# Altium schematic sheet Sch2 - Switch e sensor.SchDoc("Sch2 - Switch e sensor")
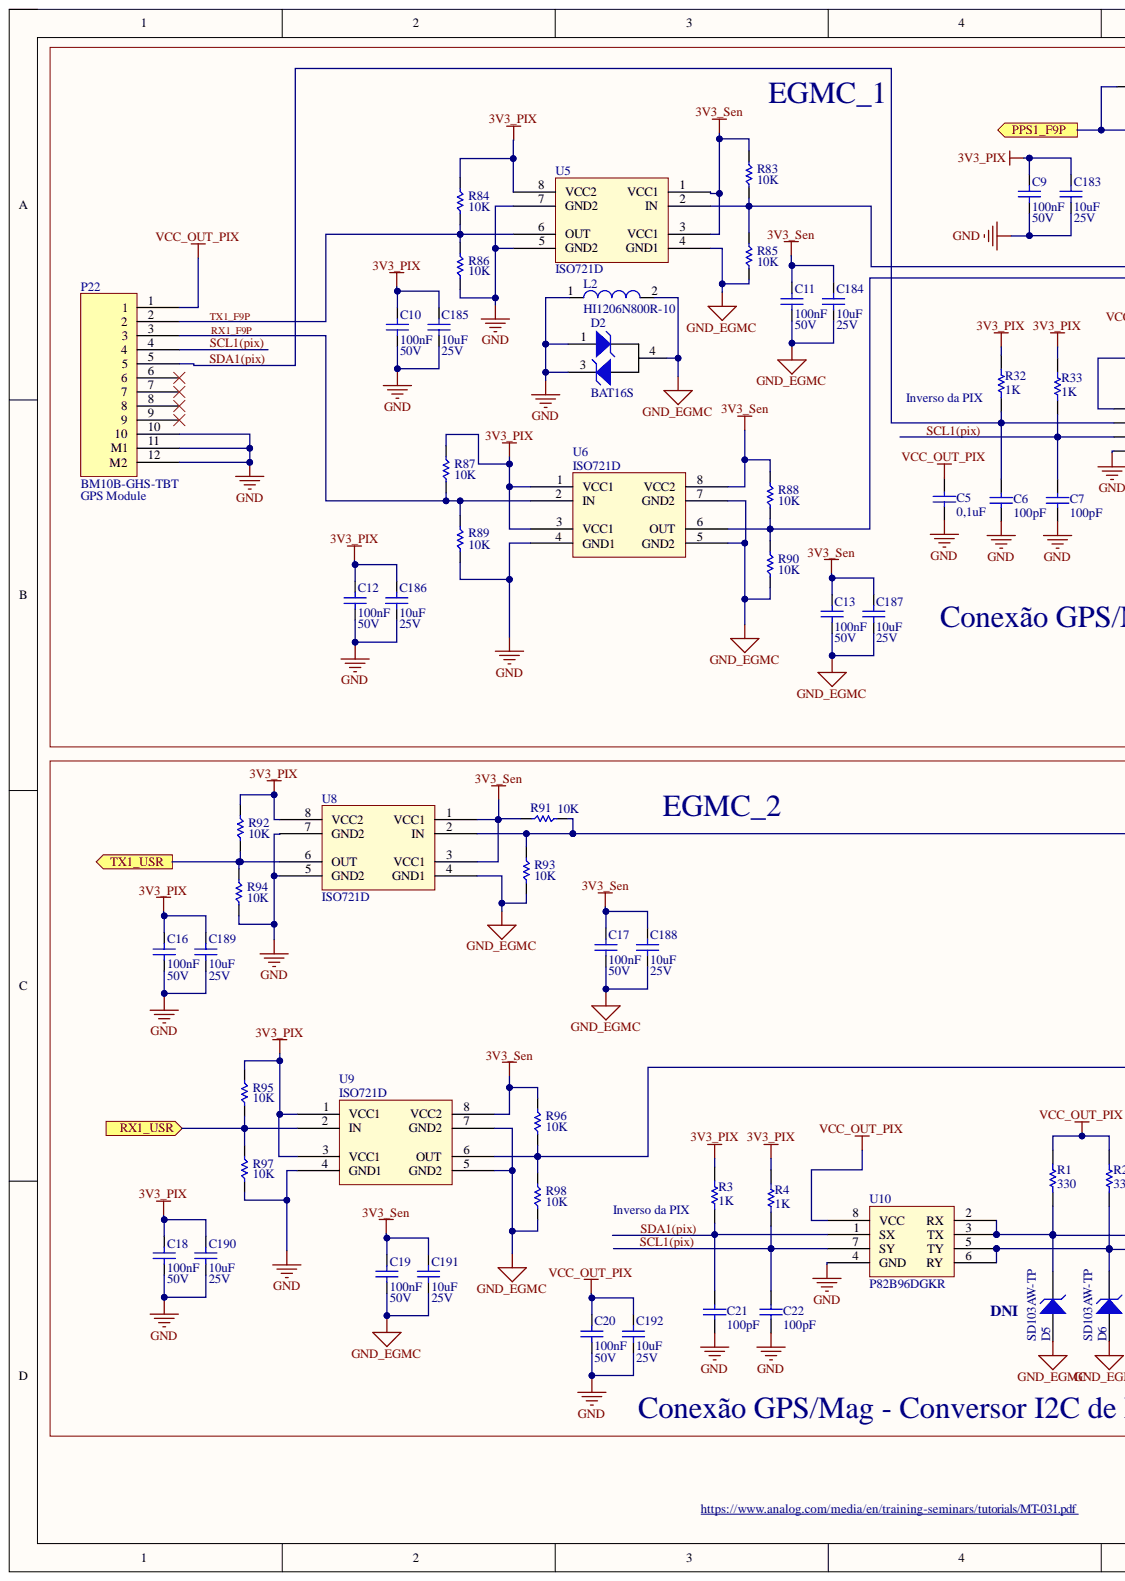
[diagram p0: Sch2 - Switch e sensor.SchDoc, left]
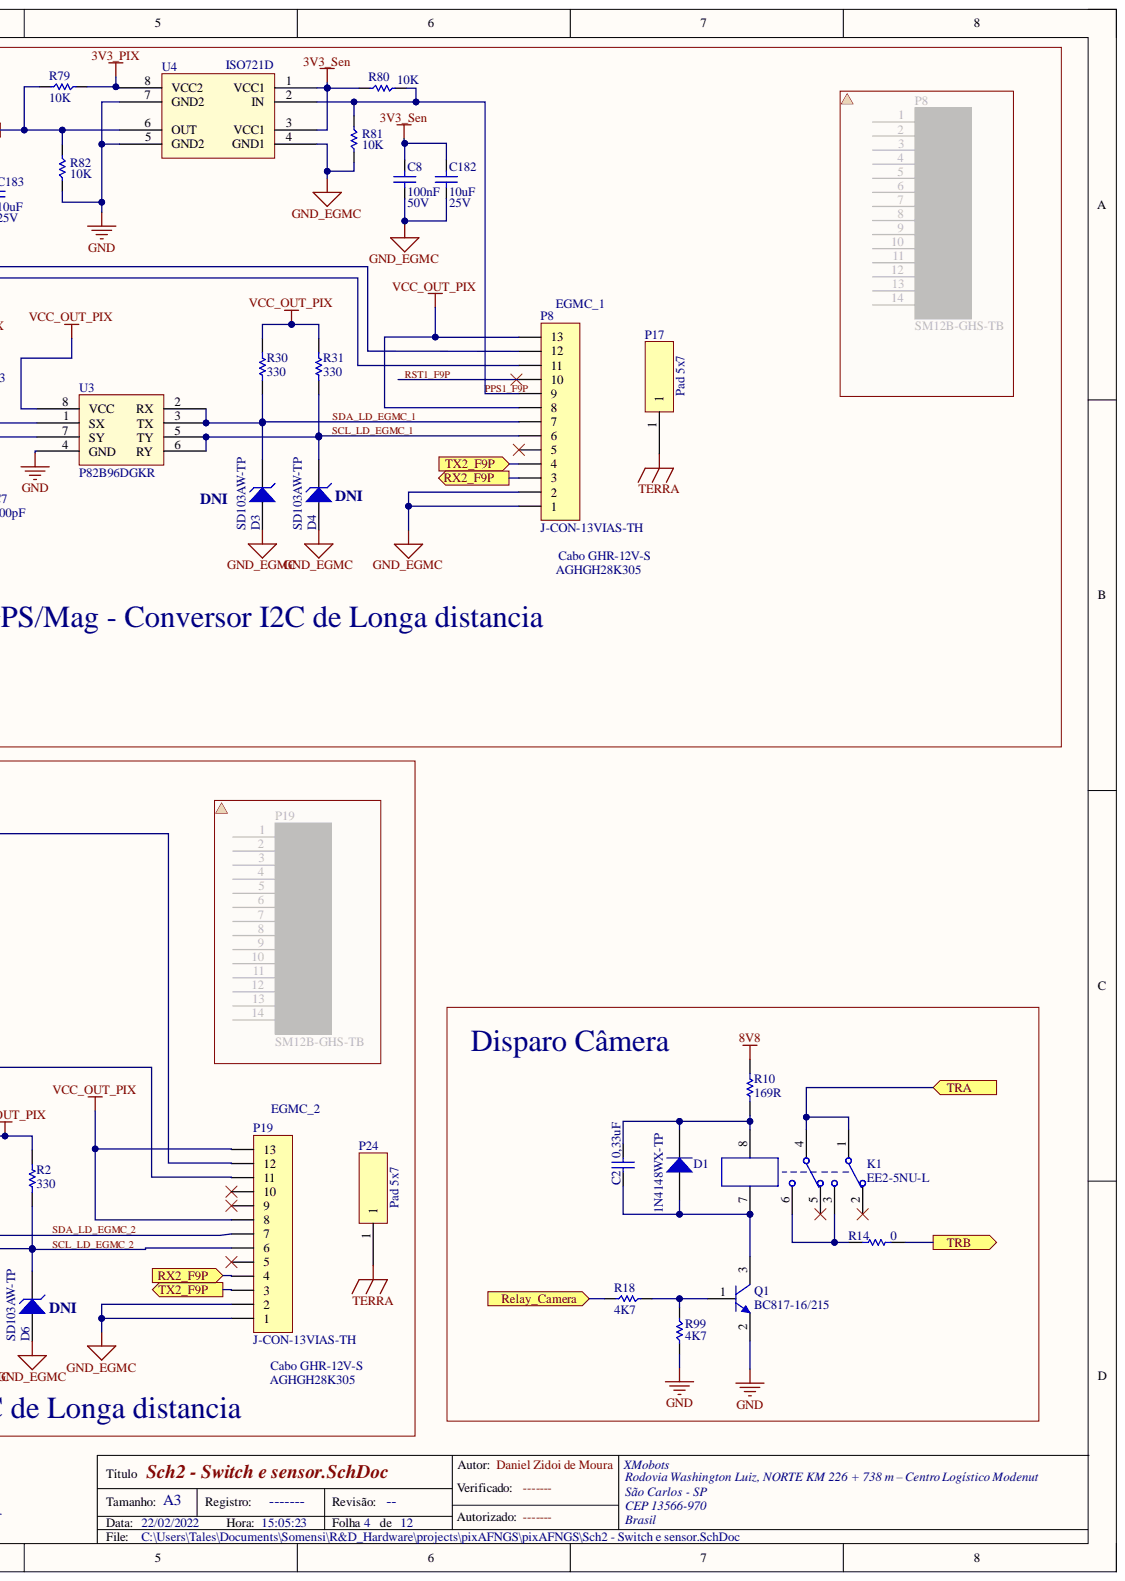
[diagram p1: Sch2 - Switch e sensor.SchDoc, right]

## Parts
C2  value 0,33uF  [0603]  (hidden comment: Cap Semi)
C5  value 0,1uF  [0603]  (hidden comment: Cap Semi)
C6  value 100pF  [0603]  (hidden comment: Cap Semi)
C7  value 100pF  [0603]  (hidden comment: Cap Semi)
C8  Capacitance: 100nF  Voltage: 50V  [0603]  (hidden comment: CL10B104MB8NNNC)
C9  Capacitance: 100nF  Voltage: 50V  [0603]  (hidden comment: CL10B104MB8NNNC)
C10  Capacitance: 100nF  Voltage: 50V  [0603]  (hidden comment: CL10B104MB8NNNC)
C11  Capacitance: 100nF  Voltage: 50V  [0603]  (hidden comment: CL10B104MB8NNNC)
C12  Capacitance: 100nF  Voltage: 50V  [0603]  (hidden comment: CL10B104MB8NNNC)
C13  Capacitance: 100nF  Voltage: 50V  [0603]  (hidden comment: CL10B104MB8NNNC)
C16  Capacitance: 100nF  Voltage: 50V  [0603]  (hidden comment: CL10B104MB8NNNC)
C17  Capacitance: 100nF  Voltage: 50V  [0603]  (hidden comment: CL10B104MB8NNNC)
C18  Capacitance: 100nF  Voltage: 50V  [0603]  (hidden comment: CL10B104MB8NNNC)
C19  Capacitance: 100nF  Voltage: 50V  [0603]  (hidden comment: CL10B104MB8NNNC)
C20  Capacitance: 100nF  Voltage: 50V  [0603]  (hidden comment: CL10B104MB8NNNC)
C21  value 100pF  [0603]  (hidden comment: Cap Semi)
C22  value 100pF  [0603]  (hidden comment: Cap Semi)
C182  Capacitance: 10uF  Voltage: 25V  [CAP-XMO-0603]  (hidden comment: GRM188R61E106KA73J)
C183  Capacitance: 10uF  Voltage: 25V  [CAP-XMO-0603]  (hidden comment: GRM188R61E106KA73J)
C184  Capacitance: 10uF  Voltage: 25V  [CAP-XMO-0603]  (hidden comment: GRM188R61E106KA73J)
C185  Capacitance: 10uF  Voltage: 25V  [CAP-XMO-0603]  (hidden comment: GRM188R61E106KA73J)
C186  Capacitance: 10uF  Voltage: 25V  [CAP-XMO-0603]  (hidden comment: GRM188R61E106KA73J)
C187  Capacitance: 10uF  Voltage: 25V  [CAP-XMO-0603]  (hidden comment: GRM188R61E106KA73J)
C188  Capacitance: 10uF  Voltage: 25V  [CAP-XMO-0603]  (hidden comment: GRM188R61E106KA73J)
C189  Capacitance: 10uF  Voltage: 25V  [CAP-XMO-0603]  (hidden comment: GRM188R61E106KA73J)
C190  Capacitance: 10uF  Voltage: 25V  [CAP-XMO-0603]  (hidden comment: GRM188R61E106KA73J)
C191  Capacitance: 10uF  Voltage: 25V  [CAP-XMO-0603]  (hidden comment: GRM188R61E106KA73J)
C192  Capacitance: 10uF  Voltage: 25V  [CAP-XMO-0603]  (hidden comment: GRM188R61E106KA73J)
D1  1N4148WX-TP  [SOD-323]
D2  BAT16S  [SOT223-4]
D3  SD103AW-TP  [SOD-123]
D4  SD103AW-TP  [SOD-123]
D5  SD103AW-TP  [SOD-123]
D6  SD103AW-TP  [SOD-123]
K1  EE2-5NU-L  [Relay TX2]
L2  HI1206N800R-10  [1206]
P8  J-CON-13VIAS-TH  [J-CON-13VIAS-TH]
P17  Pad 5x7  [Pad de solda 5x7]
P19  J-CON-13VIAS-TH  [J-CON-13VIAS-TH]
P22  BM10B-GHS-TBT  [BM10B-GHS-TBT]
P24  Pad 5x7  [Pad de solda 5x7]
Q1  BC817-16/215  [SOT23]
R1  value 330  [0603]  (hidden comment: Res Semi)
R2  value 330  [0603]  (hidden comment: Res Semi)
R3  value 1K  [0603]  (hidden comment: Res Semi)
R4  value 1K  [0603]  (hidden comment: Res Semi)
R10  value 169R  [1206]  (hidden comment: RC1206FR-07169RL)
R14  value 0  [0805]  (hidden comment: Res Semi)
R18  Resistance: 4K7  [Res_0603]  (hidden comment: RMCF0603FT4K70)
R30  value 330  [0603]  (hidden comment: Res Semi)
R31  value 330  [0603]  (hidden comment: Res Semi)
R32  value 1K  [0603]  (hidden comment: Res Semi)
R33  value 1K  [0603]  (hidden comment: Res Semi)
R79  Resistance: 10K  [RES-XMO-0603]  (hidden comment: RMCF0603FT10K0)
R80  Resistance: 10K  [RES-XMO-0603]  (hidden comment: RMCF0603FT10K0)
R81  Resistance: 10K  [RES-XMO-0603]  (hidden comment: RMCF0603FT10K0)
R82  Resistance: 10K  [RES-XMO-0603]  (hidden comment: RMCF0603FT10K0)
R83  Resistance: 10K  [RES-XMO-0603]  (hidden comment: RMCF0603FT10K0)
R84  Resistance: 10K  [RES-XMO-0603]  (hidden comment: RMCF0603FT10K0)
R85  Resistance: 10K  [RES-XMO-0603]  (hidden comment: RMCF0603FT10K0)
R86  Resistance: 10K  [RES-XMO-0603]  (hidden comment: RMCF0603FT10K0)
R87  Resistance: 10K  [RES-XMO-0603]  (hidden comment: RMCF0603FT10K0)
R88  Resistance: 10K  [RES-XMO-0603]  (hidden comment: RMCF0603FT10K0)
R89  Resistance: 10K  [RES-XMO-0603]  (hidden comment: RMCF0603FT10K0)
R90  Resistance: 10K  [RES-XMO-0603]  (hidden comment: RMCF0603FT10K0)
R91  Resistance: 10K  [RES-XMO-0603]  (hidden comment: RMCF0603FT10K0)
R92  Resistance: 10K  [RES-XMO-0603]  (hidden comment: RMCF0603FT10K0)
R93  Resistance: 10K  [RES-XMO-0603]  (hidden comment: RMCF0603FT10K0)
R94  Resistance: 10K  [RES-XMO-0603]  (hidden comment: RMCF0603FT10K0)
R95  Resistance: 10K  [RES-XMO-0603]  (hidden comment: RMCF0603FT10K0)
R96  Resistance: 10K  [RES-XMO-0603]  (hidden comment: RMCF0603FT10K0)
R97  Resistance: 10K  [RES-XMO-0603]  (hidden comment: RMCF0603FT10K0)
R98  Resistance: 10K  [RES-XMO-0603]  (hidden comment: RMCF0603FT10K0)
R99  Resistance: 4K7  [RES-XMO-0603]  (hidden comment: RMCF0603FT4K70)
U3  P82B96DGKR  [MSOP_8]
U4  ISO721D  [SOIC-8_D-PDSO-G8]
U5  ISO721D  [SOIC-8_D-PDSO-G8]
U6  ISO721D  [SOIC-8_D-PDSO-G8]
U8  ISO721D  [SOIC-8_D-PDSO-G8]
U9  ISO721D  [SOIC-8_D-PDSO-G8]
U10  P82B96DGKR  [MSOP_8]

## Ports
PPS1_F9P, Relay_Camera, RX1_USR, RX2_F9P, TRA, TRB, TX1_USR, TX2_F9P

## Nets
3V3_PIX: C9.2 C10.2 C12.2 C16.2 C18.2 C183.2 C185.2 C186.2 C189.2 C190.2 R3.1 R4.1 R32.1 R33.1 R79.2 R84.2 R87.2 R92.2 R95.2 U4.8(VCC2) U5.8(VCC2) U6.1(VCC1) U6.3(VCC1) U8.8(VCC2) U9.1(VCC1) U9.3(VCC1)
3V3_Sen: C8.2 C11.2 C13.2 C17.2 C19.2 C182.2 C184.2 C187.2 C188.2 C191.2 R80.2 R83.2 R88.2 R91.2 R96.2 U4.1(VCC1) U4.3(VCC1) U5.1(VCC1) U5.3(VCC1) U6.8(VCC2) U8.1(VCC1) U8.3(VCC1) U9.8(VCC2)
8V8: R10.1
GND: C5.1 C6.1 C7.1 C9.1 C10.1 C12.1 C16.1 C18.1 C20.1 C21.1 C22.1 C183.1 C185.1 C186.1 C189.1 C190.1 C192.1 D2.1 D2.3 L2.1 P22.10 P22.11(M1) P22.12(M2) Q1.2 R82.1 R86.1 R89.1 R94.1 R97.1 R99.1 U3.4(GND) U4.5(GND2) U4.7(GND2) U5.5(GND2) U5.7(GND2) U6.4(GND1) U8.5(GND2) U8.7(GND2) U9.4(GND1) U10.4(GND)
GND_EGMC: C8.1 C11.1 C13.1 C17.1 C19.1 C182.1 C184.1 C187.1 C188.1 C191.1 D2.4 D3.1 D4.1 D5.1 D6.1 L2.2 P8.1 P8.2 P19.1 P19.2 R81.1 R85.1 R90.1 R93.1 R98.1 U4.4(GND1) U5.4(GND1) U6.5(GND2) U6.7(GND2) U8.4(GND1) U9.5(GND2) U9.7(GND2)
TERRA: P17.1 P24.1
VCC_OUT_PIX: C5.2 C20.2 C192.2 P8.8 P8.13 P19.8 P19.13 P22.1 R1.1 R2.1 R30.1 R31.1 U3.8(VCC) U10.8(VCC)
PPS1_F9P: P8.9 R80.1 R81.2 U4.2(IN)
Relay_Camera: R18.1 ; port Relay_Camera
RST1_F9P: P8.10
RX1_F9P: P22.3 R87.1 R89.2 U6.2(IN)
RX1_USR: R95.1 R97.2 U9.2(IN) ; port RX1_USR
RX2_F9P: P8.3 P19.4 ; port RX2_F9P
SCL1(pix): C7.2 C22.2 P22.4 R4.2 R33.2 U3.7(SY) U10.7(SY)
SCL_LD_EGMC_1: D4.2 P8.6 R31.2 U3.5(TY) U3.6(RY)
SCL_LD_EGMC_2: D6.2 P19.6 R2.2 U10.5(TY) U10.6(RY)
SDA1(pix): C6.2 P22.5 R32.2 U3.1(SX)
SDA_LD_EGMC_1: D3.2 P8.7 R30.2 U3.2(RX) U3.3(TX)
SDA_LD_EGMC_2: D5.2 P19.7 R1.2 U10.2(RX) U10.3(TX)
TRA: K1.1 K1.4 ; port TRA
TRB: R14.1 ; port TRB
TX1_F9P: P22.2 R84.1 R86.2 U5.6(OUT)
TX1_USR: R92.1 R94.2 U8.6(OUT) ; port TX1_USR
TX2_F9P: P8.4 P19.3 ; port TX2_F9P
NetC2_1: C2.1 D1.1 K1.7 Q1.3
NetC2_2: C2.2 D1.2 K1.8 R10.2
NetC21_2: C21.2 R3.2 U10.1(SX)
NetK1_3: K1.3 K1.6 R14.2
NetP8_11: P8.11 R88.1 R90.2 U6.6(OUT)
NetP8_12: P8.12 R83.1 R85.2 U5.2(IN)
NetP19_11: P19.11 R96.1 R98.2 U9.6(OUT)
NetP19_12: P19.12 R91.1 R93.2 U8.2(IN)
NetQ1_1: Q1.1 R18.2 R99.2
NetR79_1: R79.1 R82.2 U4.6(OUT) ; port PPS1_F9P

Not connected: D2 2; K1 2 5; P8 5; P19 5 9 10; P22 6 7 8 9

## Library data of the parts
C2 C5-C7 C21 C22 = Cap Semi: Capacitor (Semiconductor SIM Model); Component Kind: Standard; Library Name: Miscellaneous Devices.IntLib; PackageReference: C1206; Published: 8-Jun-2000; Signal Integrity: Cap Semi; Simulation: CAP; Publisher: Altium Limited
  C2: LatestRevisionDate: 17-Jul-2002; LatestRevisionNote: Re-released for DXP Platform.
  C5-C7 C21 C22: LatestRevisionDate: 29-May-2009; LatestRevisionNote: IPC-7351 Footprint Added.; PackageDescription: Chip Capacitor
C8-C13 C16-C20 = C-100nF-50V-X7R-0603: Capacitor 100nF 50V X7R 0603; Component Kind: Standard; Part Number: CL10B104MB8NNNC; Library Name: xmobots_-_comp_library.IntLib
C182-C192 = C-CER-10uF-25V-X5R-0603: Capacitor 10uF 25V X5R 0603; Component Kind: Standard; Part Number: GRM188R61E106KA73J; Library Name: xmobots_-_comp_library.IntLib
D1 = 1N4148WX-TP: DIODE SWITCHING 75V 0.15A SOD323; Component Kind: Standard; Supplier 1: Digi-Key; Supplier Part Number 1: 1N4148WXTPMSCT-ND; Library Name: XM_Diodes.SchLib
D2 = BAT16S: DIODE ARRAY SCHOTTKY 60V SOT223; Component Kind: Standard; Library Name: XM_Diodes.SchLib
D3-D6 = SD103AW-TP: DIODE SCHOTTKY 40V 350MA SOD123; Component Kind: Standard; Supplier 1: Digi-Key; Supplier Part Number 1: SD103AWTPMSCT-ND; Library Name: XM_Diodes.SchLib
K1 = Relay-DPDT: Dual-Pole Dual-Throw Relay; Component Kind: Standard; LatestRevisionDate: 17-Jul-2002; LatestRevisionNote: Re-released for DXP Platform.; Library Name: Miscellaneous Devices.IntLib; PackageReference: DIP-P8; Published: 24-Mar-1999; Simulation: DPDTRELAY; Publisher: Altium Limited
L2 = BLM18SG221TN1D: FERRITE CHIP 220 OHM 2500MA 0603; Component Kind: Standard; Supplier 1: Digi-Key; Supplier Part Number 1: 490-5225-1-ND; Library Name: XM_Inductor.SchLib
P17 P24 = 1528-2: TERMINAL TURRET SINGLE .082" L; Component Kind: Standard; Supplier 1: Digi-Key; Supplier Part Number 1: 1528-2K-ND; Library Name: XM_Connector.SchLib
P22 = BM10B-GHS-TBT: JST GH 10 Vias Vertical; Component Kind: Standard; Library Name: XM_Connector.SchLib
Q1 = 2N3904: NPN General Purpose Amplifier; Code_JEDEC: TO-92A; Component Kind: Standard; ComponentLink1Description: Manufacturer Link; ComponentLink1URL: http://www.fairchildsemi.com/; ComponentLink2Description: Datasheet; ComponentLink2URL: http://www.fairchildsemi.com/ds/2N%2F2N3904.pdf; DatasheetVersion: 1997; LatestRevisionDate: 15-Jan-2003; LatestRevisionNote: PCB Footprint 'BCY-W3/D4.7' replaced; Library Name: Miscellaneous Devices.IntLib; PackageReference: TO-92A; PackageVersion: Sep-1998; Published: 8-Jun-2000; Signal Integrity: 2N3904; Simulation: 2N3904; Publisher: Altium Limited
R1-R4 R10 R14 R30-R33 = Res Semi: Semiconductor Resistor; Component Kind: Standard; LatestRevisionDate: 17-Jul-2002; LatestRevisionNote: Re-released for DXP Platform.; Library Name: Miscellaneous Devices.IntLib; PackageReference: AXIAL-0.5; Published: 8-Jun-2000; Signal Integrity: Res Semi; Simulation: RES; Publisher: Altium Limited
R18 R99 = R-4K7-1/10W-1%-0603: Resistor 4k7 ohms 1/10W 1% 0603; Component Kind: Standard; Part Number: RMCF0603FT4K70; Power: 1/10W; Tolerance: ±1%; Library Name: xmobots_-_comp_library.IntLib
R79-R98 = R-10K-1/10W-1%-0603: Resistor 10k ohms 1/10W 1% 0603; Component Kind: Standard; Part Number: RMCF0603FT10K0; Power: 1/10W; Tolerance: ±1%; Library Name: xmobots_-_comp_library.IntLib
U3 U10 = P82B96DGKR: Dual bidirectional bus buffer; Component Kind: Standard; Supplier 1: Digi-Key; Supplier Part Number 1: 296-20941-1-ND; Library Name: XM_IC.SchLib
U4-U6 U8 U9 = ISO721D: 3.3-V / 5-V HIGH-SPEED DIGITAL ISOLATORS; Component Kind: Standard; Supplier 1: Digi-Key; Supplier Part Number 1: 296-19382-ND; Library Name: XM_IC.SchLib
P8 P19 = J-CON-13VIAS-TH: Component Kind: Standard; Library Name: WarLib.IntLib
